# Altium schematic sheet Power_Supply.SchDoc
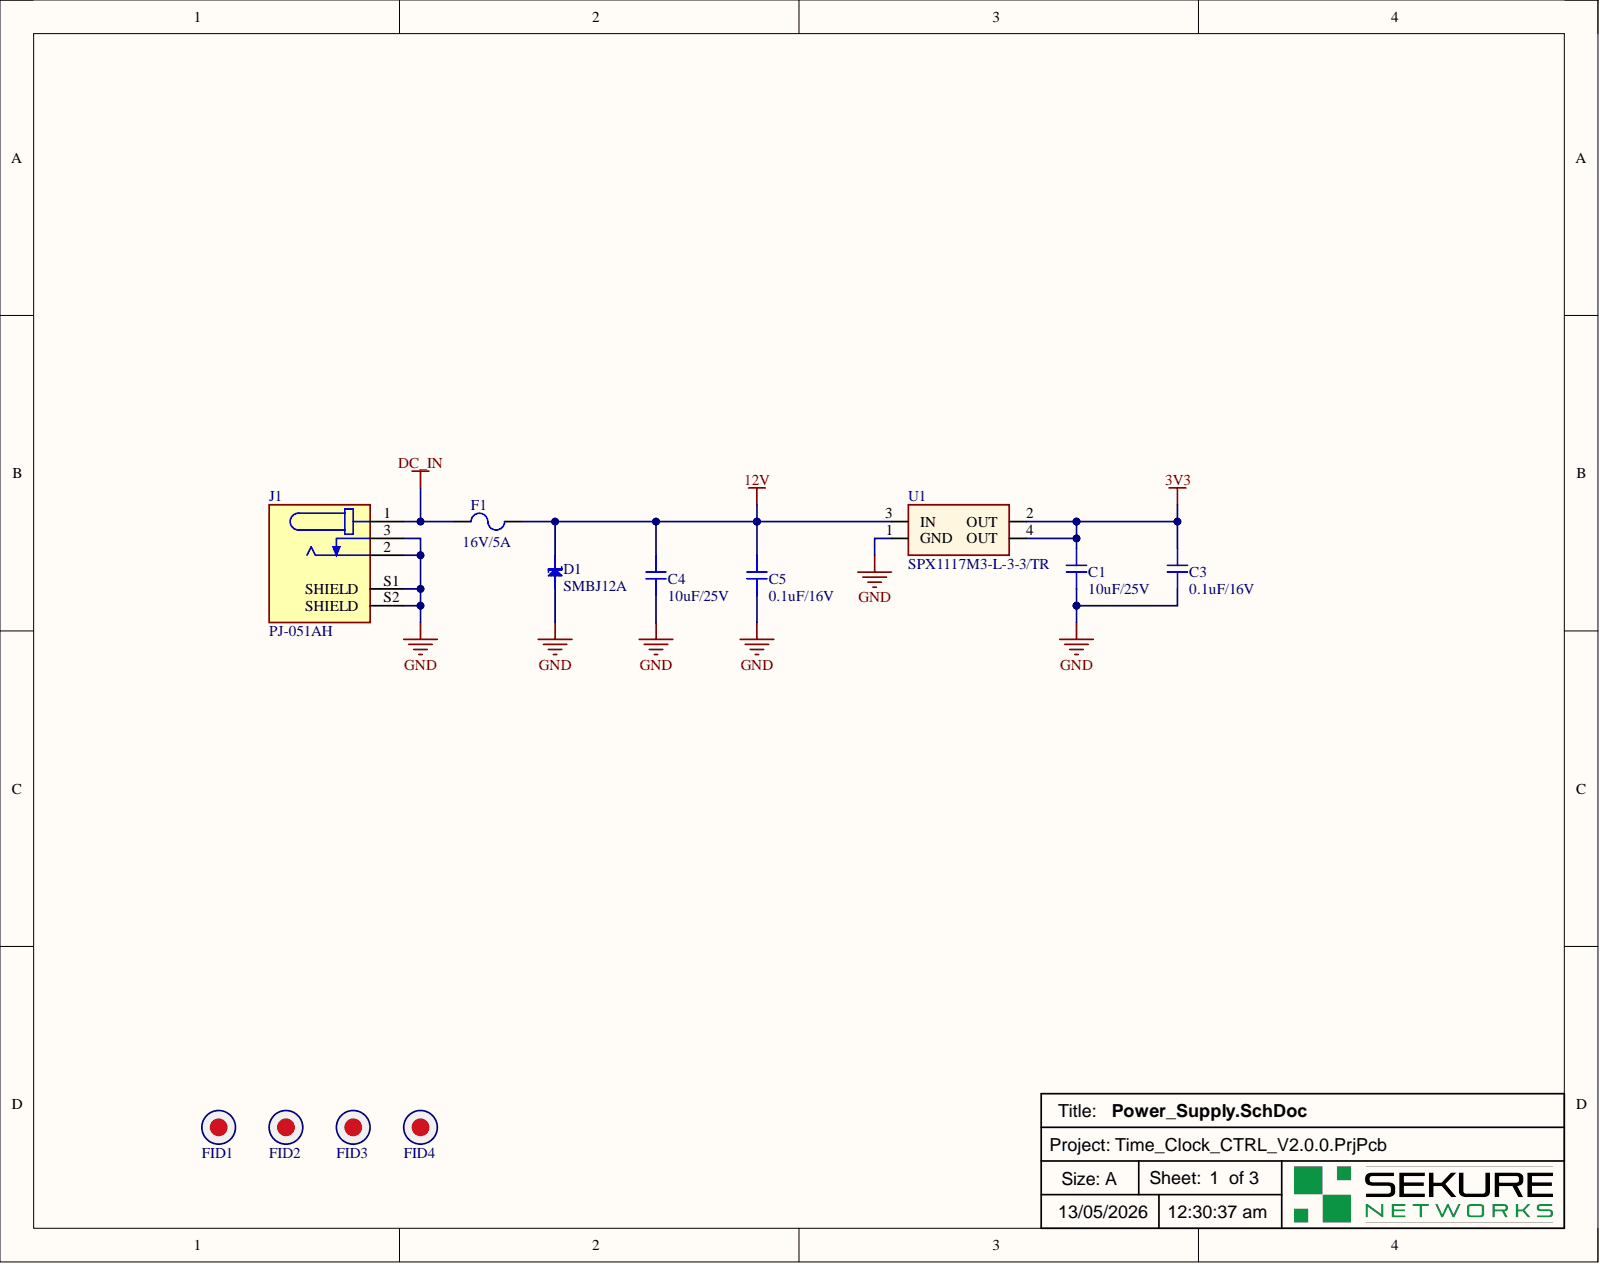
[diagram p0: Power_Supply.SchDoc]

## Parts
C1  value 10uF/25V  [Cap 0805]  (hidden comment: Ceramic Capacitor)
C3  value 0.1uF/16V  [CAP 0603]  (hidden comment: Ceramic Capacitor)
C4  value 10uF/25V  [Cap 0805]  (hidden comment: Ceramic Capacitor)
C5  value 0.1uF/16V  [CAP 0603]  (hidden comment: Ceramic Capacitor)
D1  value SMBJ12A  [DO-214AA, SMB]  (hidden comment: TVS Diode)
F1  value 16V/5A  [0ZCF0500FF2A]  (hidden comment: Fuse)
FID1  Fiducal marks  [Fiducial mark]  (value not drawn)  (no BOM)
FID2  Fiducal marks  [Fiducial mark]  (value not drawn)  (no BOM)
FID3  Fiducal marks  [Fiducial mark]  (value not drawn)  (no BOM)
FID4  Fiducal marks  [Fiducial mark]  (value not drawn)  (no BOM)
J1  value PJ-051AH  [PJ-051AH]  (hidden comment: Connector)
U1  value SPX1117M3-L-3-3/TR  [SOT-223]  (hidden comment: Regulator)

## Nets
3V3: C1.2 C3.1 U1.2(OUT) U1.4(OUT)
12V: C4.1 C5.2 D1.1 F1.2 U1.3(IN)
DC_IN: F1.1 J1.1
GND: C1.1 C3.2 C4.2 C5.1 D1.2 J1.2 J1.3 J1.S1(SHIELD) J1.S2(SHIELD) U1.1(GND)

Not connected: FID1 0; FID2 0; FID3 0; FID4 0

## Library data of the parts
C1 C4 = CL21A106KAYNNNG: 25V 10uF X5R 卤10% 0805 Multilayer Ceramic Capacitors MLCC - SMD/SMT ROHS; Manufacturer: Samsung Electro-Mechanics; Tolerance: 10%; Case Code (Imperial): 0805; Case Code (Metric): 2012; Case/Package: 0805; Category: Ceramic Capacitors; China RoHS: Compliant; Component Kind: Standard; ComponentLink1Description: https://datasheet.octopart.com:443/CL21A106KAYNNNG-Samsung-Electro-Mechanics-datasheet-181551474.pdf?src-supplier=Newark; ComponentLink1URL: https://datasheet.octopart.com:443/CL21A106KAYNNNG-Samsung-Electro-Mechanics-datasheet-181551474.pdf?src-supplier=Newark; ComponentLink2Description: https://datasheet.octopart.com:443/CL21A106KAYNNNG-Samsung-Electro-Mechanics-datasheet-136328974.pdf?src-supplier=Newark; ComponentLink2URL: https://datasheet.octopart.com:443/CL21A106KAYNNNG-Samsung-Electro-Mechanics-datasheet-136328974.pdf?src-supplier=Newark; ComponentLink3Description: https://datasheet.octopart.com:443/CL21A106KAYNNNG-Samsung-Electro-Mechanics-datasheet-136031795.pdf?src-supplier=Samsung+SCT; ComponentLink3URL: https://datasheet.octopart.com:443/CL21A106KAYNNNG-Samsung-Electro-Mechanics-datasheet-136031795.pdf?src-supplier=Samsung+SCT; ComponentLink4Description: https://datasheet.octopart.com:443/CL21A106KAYNNNG-Samsung-Electro-Mechanics-datasheet-110226396.pdf?src-supplier=Future+Electronics; ComponentLink4URL: https://datasheet.octopart.com:443/CL21A106KAYNNNG-Samsung-Electro-Mechanics-datasheet-110226396.pdf?src-supplier=Future+Electronics; ComponentLink5Description: https://datasheet.octopart.com:443/CL21A106KAYNNNG-Samsung-Electro-Mechanics-datasheet-81462119.pdf?src-supplier=Future+Electronics; ComponentLink5URL: https://datasheet.octopart.com:443/CL21A106KAYNNNG-Samsung-Electro-Mechanics-datasheet-81462119.pdf?src-supplier=Future+Electronics; ComponentLink6Description: https://datasheet.octopart.com:443/CL21A106KAYNNNG-Samsung-datasheet-66660282.pdf?src-supplier=Future+Electronics; ComponentLink6URL: https://datasheet.octopart.com:443/CL21A106KAYNNNG-Samsung-datasheet-66660282.pdf?src-supplier=Future+Electronics; ComponentLink7Description: https://datasheet.octopart.com:443/CL21A106KAYNNNG-Samsung-datasheet-48647533.pdf?src-supplier=Future+Electronics; ComponentLink7URL: https://datasheet.octopart.com:443/CL21A106KAYNNNG-Samsung-datasheet-48647533.pdf?src-supplier=Future+Electronics; ComponentLink8Description: https://datasheet.octopart.com:443/CL21A106KAYNNNG-Samsung-datasheet-41571805.pdf?src-supplier=Future+Electronics; ComponentLink8URL: https://datasheet.octopart.com:443/CL21A106KAYNNNG-Samsung-datasheet-41571805.pdf?src-supplier=Future+Electronics; ComponentLink9Description: https://datasheet.octopart.com:443/CL21A106KAYNNNG-Samsung-Electro-Mechanics-datasheet-149154150.pdf?src-supplier=Future+Electronics; ComponentLink9URL: https://datasheet.octopart.com:443/CL21A106KAYNNNG-Samsung-Electro-Mechanics-datasheet-149154150.pdf?src-supplier=Future+Electronics; ComponentLink10Description: https://datasheet.octopart.com/CL21A106KAYNNNG-Samsung-Electro-Mechanics-datasheet-97040617.pdf; ComponentLink10URL: https://datasheet.octopart.com/CL21A106KAYNNNG-Samsung-Electro-Mechanics-datasheet-97040617.pdf; ComponentLink11Description: https://datasheet.octopart.com/General-Multilayer-Ceramic-Capacitors-Samsung-datasheet-family-111.pdf; ComponentLink11URL: https://datasheet.octopart.com/General-Multilayer-Ceramic-Capacitors-Samsung-datasheet-family-111.pdf; ComponentLink12Description: https://datasheet.octopart.com:443/CL21A106KAYNNNG-Samsung-datasheet-59220082.pdf?src-supplier=TME; ComponentLink12URL: https://datasheet.octopart.com:443/CL21A106KAYNNNG-Samsung-datasheet-59220082.pdf?src-supplier=TME; ComponentLink13Description: http://sigma.octopart.com:80/rohs_certificate/General-Multilayer-Ceramic-Capacitors-Samsung-family-110.pdf?src-supplier=Compliance+Statements; ComponentLink13URL: http://sigma.octopart.com:80/rohs_certificate/General-Multilayer-Ceramic-Capacitors-Samsung-family-110.pdf?src-supplier=Compliance+Statements; ComponentLink14Description: http://sigma.octopart.com:80/rohs_statement/General-Multilayer-Ceramic-Capacitors-Samsung-family-132.pdf?src-supplier=Compliance+Statements; ComponentLink14URL: http://sigma.octopart.com:80/rohs_statement/General-Multilayer-Ceramic-Capacitors-Samsung-family-132.pdf?src-supplier=Compliance+Statements; ComponentLink15Description: http://sigma.octopart.com:80/reach_statement/General-Multilayer-Ceramic-Capacitors-Samsung-family-133.pdf?src-supplier=Compliance+Statements; ComponentLink15URL: http://sigma.octopart.com:80/reach_statement/General-Multilayer-Ceramic-Capacitors-Samsung-family-133.pdf?src-supplier=Compliance+Statements; Country of Origin: Mainland China, Philippines, South Korea; Depth: 1.25mm; Dielectric: X5R; Dielectric Material: Ceramic; DigiKey: 1276-6454-1-ND; Export Control Classification Number (ECCN) Code: EAR99; Harmonized Tariff Schedule (HTS) Code: 8532.24.00.20; Height: 1.25mm; Introduction Date: 2011-04-20; Lead Free: Lead Free; Length: 2mm; Library Name: Ceramic Capacitors.IntLib; Lifecycle Status: Production; Material: Ceramic; Max Operating Temperature: 85°C; Min Operating Temperature: -55°C; Mounting Technology: Surface Mount; Number of Terminals: 2; P/N: CL21A106KAYNNNG; Packaging: Tape & Reel; Pricing: 1=0.16, 10=0.089, 50=0.0628, 100=0.0546, 500=0.04102, 1000=0.03683 (USD); Radiation Hardening: No; REACH SVHC: Yes; RoHS: Compliant; Schedule B: 8532240020, 8532240020|8532240020|8532240020|8532240020|8532240020|8532240020|8532240020|8532240020|8532240020|8532240020|8532240020|8532240020|8532240020|8532240020|8532240020|8532240020|8532240020|8532240020|8532240020|8532240020|8532240020|8532240020|8532240020|85; Stock: 176173; Supplier: DigiKey; Thickness: 1.4478mm; Voltage Rating: 25V; Voltage Rating (DC): 25V; Width: 1.25mm
C3 C5 = CL10B104KO8NNNC: 16V 100nF X7R ±10% 0603 Multilayer Ceramic Capacitors MLCC - SMD/SMT ROHS; Manufacturer: Samsung Electro-Mechanics; Case Code (Imperial): 0603; Case Code (Metric): 1608; Case/Package: 0603; Category: Ceramic Capacitors; China RoHS: Compliant; Component Kind: Standard; ComponentLink1Description: https://datasheet.octopart.com:443/CL10B104KO8NNNC-Samsung-Electro-Mechanics-datasheet-136482305.pdf?src-supplier=IHS; ComponentLink1URL: https://datasheet.octopart.com:443/CL10B104KO8NNNC-Samsung-Electro-Mechanics-datasheet-136482305.pdf?src-supplier=IHS; ComponentLink2Description: https://datasheet.octopart.com/CL10B104KO8NNNC-Samsung-Electro-Mechanics-datasheet-8324245.pdf; ComponentLink2URL: https://datasheet.octopart.com/CL10B104KO8NNNC-Samsung-Electro-Mechanics-datasheet-8324245.pdf; ComponentLink3Description: https://datasheet.octopart.com/CL10B104KO8NNNC-Samsung-Electro-Mechanics-datasheet-97040617.pdf; ComponentLink3URL: https://datasheet.octopart.com/CL10B104KO8NNNC-Samsung-Electro-Mechanics-datasheet-97040617.pdf; ComponentLink4Description: https://datasheet.octopart.com:443/CL10B104KO8NNNC-Samsung-Electro-Mechanics-datasheet-116890973.pdf?src-supplier=Future+Electronics; ComponentLink4URL: https://datasheet.octopart.com:443/CL10B104KO8NNNC-Samsung-Electro-Mechanics-datasheet-116890973.pdf?src-supplier=Future+Electronics; ComponentLink5Description: https://datasheet.octopart.com:443/CL10B104KO8NNNC-Samsung-Electro-Mechanics-datasheet-81461844.pdf?src-supplier=Future+Electronics; ComponentLink5URL: https://datasheet.octopart.com:443/CL10B104KO8NNNC-Samsung-Electro-Mechanics-datasheet-81461844.pdf?src-supplier=Future+Electronics; ComponentLink6Description: https://datasheet.octopart.com:443/CL10B104KO8NNNC-Samsung-datasheet-42770384.pdf?src-supplier=Future+Electronics; ComponentLink6URL: https://datasheet.octopart.com:443/CL10B104KO8NNNC-Samsung-datasheet-42770384.pdf?src-supplier=Future+Electronics; ComponentLink7Description: https://datasheet.octopart.com:443/CL10B104KO8NNNC-Samsung-datasheet-26589157.pdf?src-supplier=Future+Electronics; ComponentLink7URL: https://datasheet.octopart.com:443/CL10B104KO8NNNC-Samsung-datasheet-26589157.pdf?src-supplier=Future+Electronics; ComponentLink8Description: https://datasheet.octopart.com:443/CL10B104KO8NNNC-Samsung-Electro-Mechanics-datasheet-149136789.pdf?src-supplier=Future+Electronics; ComponentLink8URL: https://datasheet.octopart.com:443/CL10B104KO8NNNC-Samsung-Electro-Mechanics-datasheet-149136789.pdf?src-supplier=Future+Electronics; ComponentLink9Description: https://datasheet.octopart.com:443/CL10B104KO8NNNC-Samsung-datasheet-8326407.pdf?src-supplier=TME; ComponentLink9URL: https://datasheet.octopart.com:443/CL10B104KO8NNNC-Samsung-datasheet-8326407.pdf?src-supplier=TME; ComponentLink10Description: https://datasheet.octopart.com:443/CL10B104KO8NNNC-Samsung-Electro-Mechanics-datasheet-11890603.pdf?src-supplier=Arrow+Electronics; ComponentLink10URL: https://datasheet.octopart.com:443/CL10B104KO8NNNC-Samsung-Electro-Mechanics-datasheet-11890603.pdf?src-supplier=Arrow+Electronics; Contact Plating: Tin; Depth: 800µm; Dielectric: X7R; Dielectric Material: Ceramic; DigiKey: 1276-1005-1-ND; Height: 800µm; Lead Free: Lead Free; Length: 1.6mm; Library Name: Ceramic Capacitors.IntLib; Lifecycle Status: Production; Material: Ceramic; Max Operating Temperature: 125°C; Min Operating Temperature: -55°C; Mounting Technology: Surface Mount; Number of Terminals: 2; P/N: CL10B104KO8NNNC; Pricing: 1=0.1, 10=0.026, 50=0.0172, 100=0.0146, 500=0.0103, 1000=0.00899 (USD); Radiation Hardening: No; REACH SVHC: Yes; RoHS: Compliant; Schedule B: 8532240020, 8532240020|8532240020, 8532240020|8532240020|8532240020, 8532240020|8532240020|8532240020|8532240020; Stock: 2399591; Supplier: DigiKey; Thickness: 889µm; Tolerance: 10%; Voltage: 16V; Voltage Rating: 16V; Voltage Rating (DC): 16V; Width: 800µm
D1 = SMBJ12A: SMBJ Series 19.9 V 0.6 kW Surface Mount Uni-Directional TVS Diode - DO-214AA; Manufacturer: Littelfuse; Breakdown Voltage: 14V; Clamping Voltage: 19.9V; Direction: Unidirectional; Element Configuration: Single; ESD Protection: Yes; Forward Voltage: 3.5V; Leakage Current: 1µA; Max Breakdown Voltage: 14.7V; Max Junction Temperature (Tj): 150°C; Max Power Dissipation: 5W; Max Surge Current: 30.2A; Min Breakdown Voltage: 13.3V; Number of Channels: 1; Operating Supply Voltage: 12V; Peak Pulse Power: 600W; Power Line Protection: No; Test Current: 1mA; Case/Package: SMB; Category: TVS Diodes; China RoHS: Non-Compliant; Component Kind: Standard; ComponentLink1Description: https://datasheet.octopart.com:443/SMBJ12A-Littelfuse-datasheet-180609570.pdf?src-supplier=IHS; ComponentLink1URL: https://datasheet.octopart.com:443/SMBJ12A-Littelfuse-datasheet-180609570.pdf?src-supplier=IHS; ComponentLink2Description: https://datasheet.octopart.com:443/SMBJ12A-Littelfuse-datasheet-98958142.pdf?src-supplier=Burklin+Elektronik; ComponentLink2URL: https://datasheet.octopart.com:443/SMBJ12A-Littelfuse-datasheet-98958142.pdf?src-supplier=Burklin+Elektronik; ComponentLink3Description: https://datasheet.octopart.com:443/SMBJ12A-Littelfuse-datasheet-46975802.pdf?src-supplier=Burklin+Elektronik; ComponentLink3URL: https://datasheet.octopart.com:443/SMBJ12A-Littelfuse-datasheet-46975802.pdf?src-supplier=Burklin+Elektronik; ComponentLink4Description: https://datasheet.octopart.com:443/SMBJ12A-Littelfuse-datasheet-10854588.pdf?src-supplier=element14+APAC; ComponentLink4URL: https://datasheet.octopart.com:443/SMBJ12A-Littelfuse-datasheet-10854588.pdf?src-supplier=element14+APAC; ComponentLink5Description: https://datasheet.octopart.com:443/SMBJ12A-Littelfuse-datasheet-63710821.pdf?src-supplier=element14+APAC; ComponentLink5URL: https://datasheet.octopart.com:443/SMBJ12A-Littelfuse-datasheet-63710821.pdf?src-supplier=element14+APAC; ComponentLink6Description: https://datasheet.octopart.com:443/SMBJ12A-Littelfuse-datasheet-5319440.pdf?src-supplier=element14+APAC; ComponentLink6URL: https://datasheet.octopart.com:443/SMBJ12A-Littelfuse-datasheet-5319440.pdf?src-supplier=element14+APAC; ComponentLink7Description: https://datasheet.octopart.com:443/SMBJ12A-Littelfuse-datasheet-145017494.pdf?src-supplier=Farnell; ComponentLink7URL: https://datasheet.octopart.com:443/SMBJ12A-Littelfuse-datasheet-145017494.pdf?src-supplier=Farnell; ComponentLink8Description: https://datasheet.octopart.com:443/SMBJ12A-Littelfuse-datasheet-123574.pdf?src-supplier=Farnell; ComponentLink8URL: https://datasheet.octopart.com:443/SMBJ12A-Littelfuse-datasheet-123574.pdf?src-supplier=Farnell; ComponentLink9Description: https://datasheet.octopart.com:443/SMBJ12A-Littelfuse-datasheet-871.pdf?src-supplier=DigiKey; ComponentLink9URL: https://datasheet.octopart.com:443/SMBJ12A-Littelfuse-datasheet-871.pdf?src-supplier=DigiKey; ComponentLink10Description: https://datasheet.octopart.com:443/SMBJ12A-Littelfuse-datasheet-170904961.pdf?src-supplier=Future+Electronics; ComponentLink10URL: https://datasheet.octopart.com:443/SMBJ12A-Littelfuse-datasheet-170904961.pdf?src-supplier=Future+Electronics; ComponentLink11Description: https://datasheet.octopart.com:443/SMBJ12A-Littelfuse-datasheet-27889791.pdf?src-supplier=Fuses+Unlimited; ComponentLink11URL: https://datasheet.octopart.com:443/SMBJ12A-Littelfuse-datasheet-27889791.pdf?src-supplier=Fuses+Unlimited; ComponentLink12Description: https://datasheet.octopart.com:443/SMBJ12A-Littelfuse-datasheet-9813940.pdf?src-supplier=RS+(Formerly+Allied+Electronics); ComponentLink12URL: https://datasheet.octopart.com:443/SMBJ12A-Littelfuse-datasheet-9813940.pdf?src-supplier=RS+(Formerly+Allied+Electronics); ComponentLink13Description: https://datasheet.octopart.com:443/SMBJ12A-Littelfuse-datasheet-22888.pdf?src-supplier=RS+(Formerly+Allied+Electronics); ComponentLink13URL: https://datasheet.octopart.com:443/SMBJ12A-Littelfuse-datasheet-22888.pdf?src-supplier=RS+(Formerly+Allied+Electronics); ComponentLink14Description: https://datasheet.octopart.com:443/SMBJ12A-Littelfuse-datasheet-10521649.pdf?src-supplier=Jameco; ComponentLink14URL: https://datasheet.octopart.com:443/SMBJ12A-Littelfuse-datasheet-10521649.pdf?src-supplier=Jameco; ComponentLink15Description: https://datasheet.octopart.com:443/SMBJ12A-Littelfuse-datasheet-11045459.pdf?src-supplier=Newark; ComponentLink15URL: https://datasheet.octopart.com:443/SMBJ12A-Littelfuse-datasheet-11045459.pdf?src-supplier=Newark; Composition: Zener; Country of Origin: Mainland China, Philippines; DigiKey: SMBJ12ALFCT-ND; Export Control Classification Number (ECCN) Code: EAR99; Harmonized Tariff Schedule (HTS) Code: 8541.10.00.50; Height: 2.61mm; Introduction Date: 1996-01-01; Lead Free: Lead Free; Length: 4.5466mm; Library Name: Diode.IntLib; Lifecycle Status: Production; Max Operating Temperature: 150°C; Max Reverse Leakage Current: 1µA; Min Operating Temperature: -65°C; Mounting Technology: Surface Mount; Number of Elements: 1; Number of Terminals: 2; Number of Unidirectional Channels: 1; P/N: SMBJ12A; Packaging: Cut Tape; Peak Pulse Current: 30.2A; Peak Reverse Current: 1µA; Pins: 2; Polarity: Unidirectional; Power Rating: 600W; Pricing: 1=0.32, 10=0.215, 100=0.104 (USD); Radiation Hardening: No; REACH SVHC: No; Reverse Breakdown Voltage: 13.3V; Reverse Standoff Voltage: 12V; Reverse Voltage: 12V; RoHS: Compliant; Schedule B: 8541100080, 8541100080|8541100080|8541100080|8541100080|8541100080|8541100080|8541100080|8541100080|8541100080|8541100080|8541100080|8541100080|8541100080|8541100080|8541100080|8541100080|8541100080|8541100080|8541100080|8541100080|8541100080|8541100080|8541100080|85; Stock: 76553; Supplier: DigiKey; Termination: SMD/SMT; Voltage Rating (DC): 12V; Width: 3.937mm
F1 = 0ZCF0500FF2A: Surface Mount PTC Resettable Fuse 2920 size 5A Tape Reel; Manufacturer: Bel Fuse; Maximum Resistance 1 Hour (R1max): 25mΩ; Trip Current: 10A; Working Voltage: 16V; Category: PTC Resettable Fuses; China RoHS: Compliant; Component Kind: Standard; ComponentLink1Description: https://datasheet.octopart.com:443/0ZCF0500FF2A-Bel-Fuse-datasheet-179230423.pdf?src-supplier=IHS; ComponentLink1URL: https://datasheet.octopart.com:443/0ZCF0500FF2A-Bel-Fuse-datasheet-179230423.pdf?src-supplier=IHS; ComponentLink2Description: https://datasheet.octopart.com:443/0ZCF0500FF2A-Bel-Fuse-datasheet-138895720.pdf?src-supplier=Master+Electronics; ComponentLink2URL: https://datasheet.octopart.com:443/0ZCF0500FF2A-Bel-Fuse-datasheet-138895720.pdf?src-supplier=Master+Electronics; DigiKey: 5923-0ZCF0500FF2ACT-ND; Height: 1.5mm; Hold Current: 5A; Library Name: Diode.IntLib; Lifecycle Status: Production; Max Operating Temperature: 85°C; Min Operating Temperature: -40°C; Mounting Technology: Surface Mount; Number of Terminals: 2; P/N: 0ZCF0500FF2A; Pricing: 1=1.61, 5=1.338, 10=1.235, 50=1.0246, 100=0.9453, 250=0.84952, 500=0.7833 (USD); REACH SVHC: Yes; RoHS: Compliant; Stock: 10145; Supplier: DigiKey; Width: 5.44mm
FID1-FID4 = Fiducial mark: Fiducial mark mini  in top placement.; Number: 1; Library Name: Connectors.IntLib
J1 = PJ-051AH: 2.0 x 6.0 mm, 5.0 A, Horizontal, Through Hole, Shielded, Dc Power Jack Connector; Manufacturer: CUI Devices; Category: Power Connectors; Component Kind: Standard; ComponentLink1Description: https://www.cuidevices.com/product/resource/digikeypdf/pj-051ah.pdf?src-supplier=Digikey; ComponentLink1URL: https://www.cuidevices.com/product/resource/digikeypdf/pj-051ah.pdf?src-supplier=Digikey; ComponentLink2Description: https://datasheet.octopart.com/PJ-051AH-CUI-Devices-datasheet-137760691.pdf?src-supplier=Future+Electronics; ComponentLink2URL: https://datasheet.octopart.com/PJ-051AH-CUI-Devices-datasheet-137760691.pdf?src-supplier=Future+Electronics; ComponentLink3Description: https://datasheet.octopart.com/PJ-051AH-CUI-Devices-datasheet-141000361.pdf?src-supplier=Master+Electronics; ComponentLink3URL: https://datasheet.octopart.com/PJ-051AH-CUI-Devices-datasheet-141000361.pdf?src-supplier=Master+Electronics; DigiKey: CP-051AH-ND; Library Name: Connectors.SchLib; P/N: PJ-051AH; Pricing: 1=0.63, 10=0.532, 25=0.5108, 50=0.4998, 100=0.4781, 250=0.43464, 500=0.39118, 1000=0.38261 (USD); RoHS: Yes; Stock: 71631; Supplier: Digikey
U1 = SPX1117M3-L-3-3/TR: Linear Voltage Regulator IC Positive Fixed 1 Output 800mA SOT-223-3; Manufacturer: MaxLinear, Inc.; Accuracy: 1%; Dropout Voltage: 1.3V; Input Bias Current: 4mA; Max Input Voltage: 15V; Max Output Voltage: 3.3V; Min Input Voltage: 3.8V; Number of Outputs: 1; Case/Package: SOT-223; Category: Voltage Regulators - Linear; Component Kind: Standard; ComponentLink1Description: https://datasheet.ciiva.com/2064/reg1117-2064563.pdf?src-supplier=Digi-Key; ComponentLink1URL: https://datasheet.ciiva.com/2064/reg1117-2064563.pdf?src-supplier=Digi-Key; DigiKey: 1016-1848-2-ND; Lead Free: Lead Free; Library Name: Regulators.IntLib; Max Operating Temperature: 125°C; Max Output Current: 800mA; Min Current Limit: 800mA; Min Operating Temperature: 0°C; Min Output Voltage: 3.3V; Nominal Output Voltage: 3.3V; Number of Pins: 4; Number of Regulators: 1; Output Current: 800mA; Output Type: Fixed; Output Voltage: 3.3V; Output Voltage Accuracy: 1%; P/N: SPX1117M3-L-3-3/TR; Package Quantity: 80; Packaging: Rail/Tube; Polarity: Positive; Pricing 1: 1=3.08000, 10=2.76700, 25=2.61040, 100=2.22390, 250=2.08820, 500=1.82718, 1000=1.72375 (USD); Quiescent Current: 4mA; Radiation Hardening: No; REACH SVHC: No SVHC; RoHS: Yes; RoHS Compliant: Yes; Stock 1: 3710; Supplier 1: Digi-Key
